# Altium schematic sheet Motor-X.SchDoc(Motor-X)
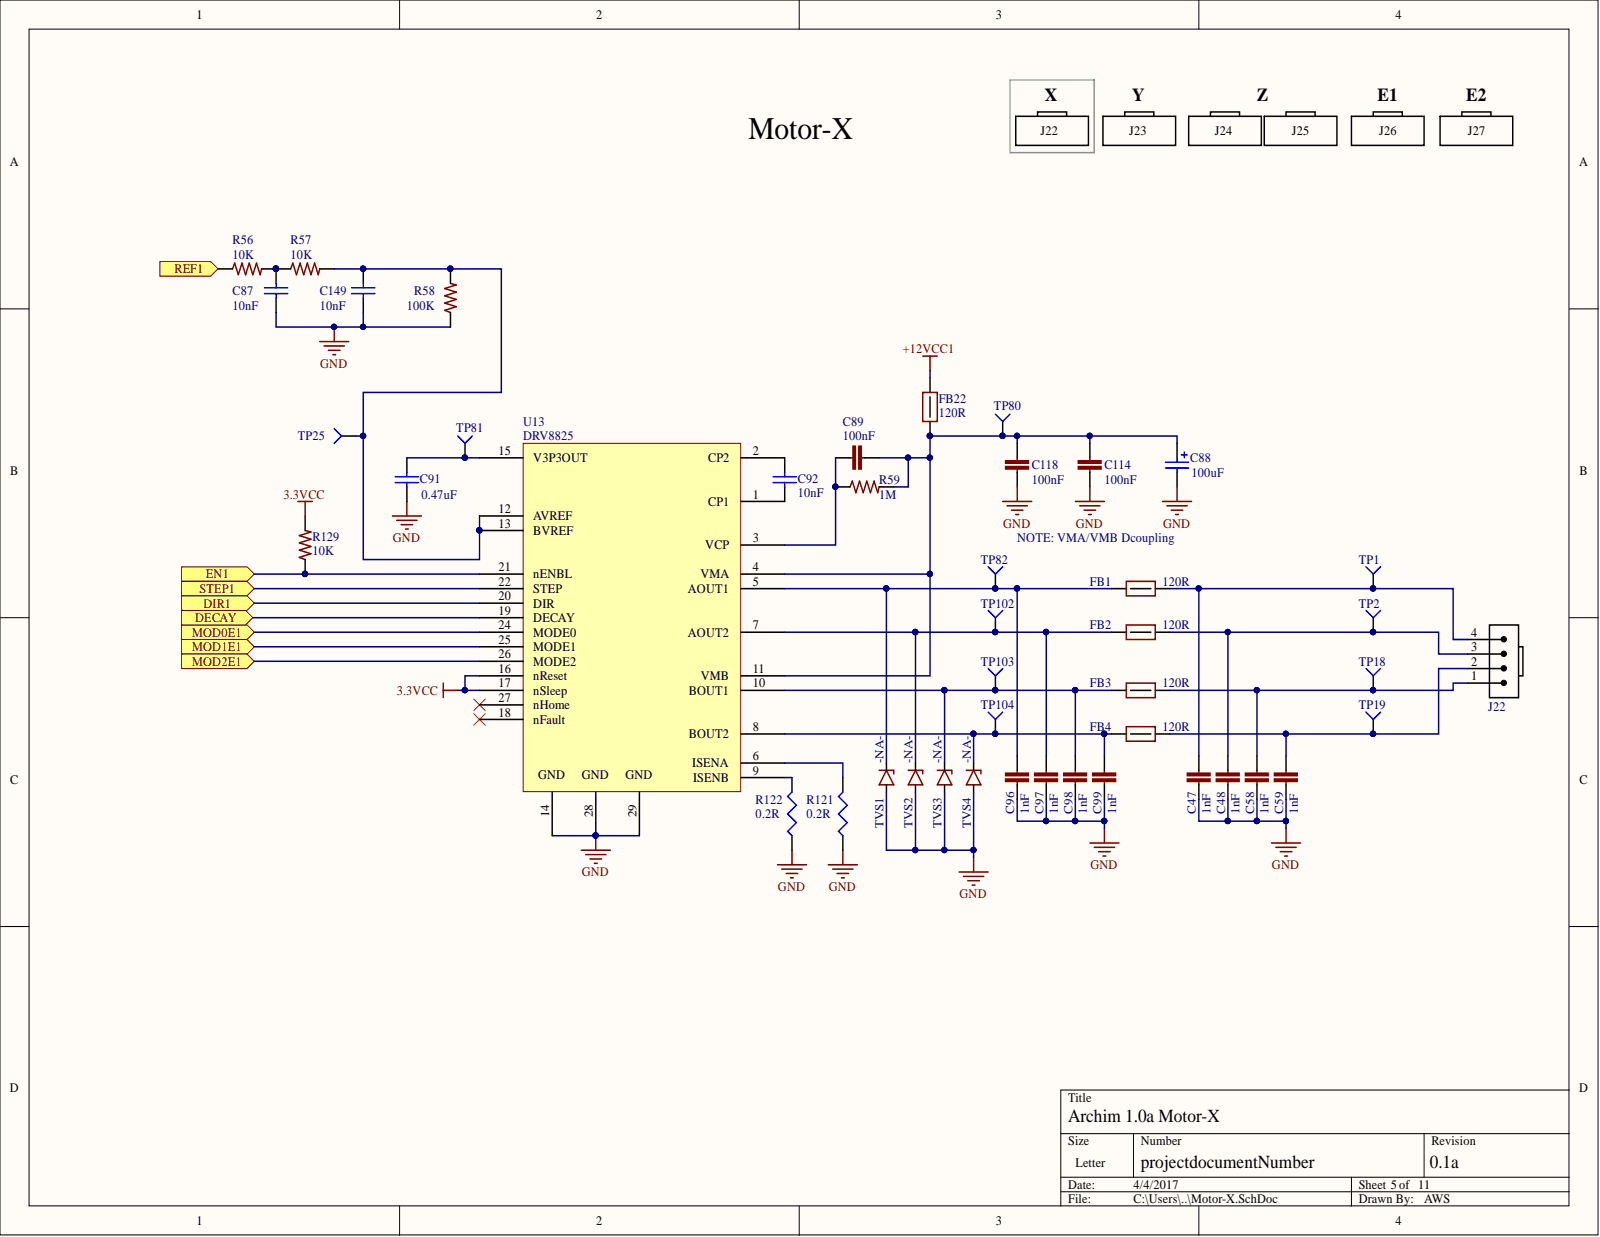
[diagram p0: Motor-X.SchDoc]

## Parts
C47  1nF  [C0402]
C48  1nF  [C0402]
C58  1nF  [C0402]
C59  1nF  [C0402]
C87  10nF  [C0402]
C88  value 100uF  [CAP-ELEC-063063077]  (hidden comment: UWT1V101MCL1GS)
C89  100nF  [C0402]
C91  value 0.47uF  [C0402]  (hidden comment: 0402)
C92  10nF  [C0402]
C96  1nF  [C0402]
C97  1nF  [C0402]
C98  1nF  [C0402]
C99  1nF  [C0402]
C114  100nF  [C0603]
C118  100nF  [C0603]
C149  10nF  [C0402]
FB1  120R  [FB0603]
FB2  120R  [FB0603]
FB3  120R  [FB0603]
FB4  120R  [FB0603]
FB22  120R  [FB0603]
J22  Molex 0705430003  [4POL254]  (value not drawn)
R56  10K  [R0402]
R57  10K  [R0402]
R58  100K  [R0402]
R59  1M  [R0402]
R121  value 0.2R  [2512R-0.55]  (hidden comment: 2512)
R122  value 0.2R  [2512R-0.55]  (hidden comment: 2512)
R129  10K  [R0402]
TP1  TP4  [TP-2]  (value not drawn)  (no BOM)
TP2  TP4  [TP-2]  (value not drawn)  (no BOM)
TP18  TP4  [TP-2]  (value not drawn)  (no BOM)
TP19  TP4  [TP-2]  (value not drawn)  (no BOM)
TP25  TP4  [TP-2]  (value not drawn)  (no BOM)
TP80  TP4  [TP-2]  (value not drawn)  (no BOM)
TP81  TP4  [TP-2]  (value not drawn)  (no BOM)
TP82  TP4  [TP-2]  (value not drawn)  (no BOM)
TP102  TP4  [TP-2]  (value not drawn)  (no BOM)
TP103  TP4  [TP-2]  (value not drawn)  (no BOM)
TP104  TP4  [TP-2]  (value not drawn)  (no BOM)
TVS1  value -NA-  [SMF]  (hidden comment: TVS 30V SMF)
TVS2  value -NA-  [SMF]  (hidden comment: TVS 30V SMF)
TVS3  value -NA-  [SMF]  (hidden comment: TVS 30V SMF)
TVS4  value -NA-  [SMF]  (hidden comment: TVS 30V SMF)
U13  DRV8825  [DRV8825PWPR]

## Ports
DECAY, DIR1, EN1, MOD0E1, MOD1E1, MOD2E1, REF1, STEP1

## Nets
3.3VCC: R129.2 U13.16(nReset) U13.17(nSleep)
+12VCC1: FB22.2
GND: C47.2 C48.2 C58.2 C59.2 C87.1 C88.2 C91.1 C96.2 C97.2 C98.2 C99.2 C114.2 C118.2 C149.1 R58.1 R121.1 R122.1 TVS1.A TVS2.A TVS3.A TVS4.A U13.14 U13.28 U13.29
DECAY: U13.19(DECAY) ; port DECAY
DIR1: U13.20(DIR) ; port DIR1
EN1: R129.1 U13.21(nENBL) ; port EN1
MOD0E1: U13.24(MODE0) ; port MOD0E1
MOD1E1: U13.25(MODE1) ; port MOD1E1
MOD2E1: U13.26(MODE2) ; port MOD2E1
REF1: R56.1 ; port REF1
STEP1: U13.22(STEP) ; port STEP1
NetC47_1: C47.1 FB1.2 J22.4 TP1.1
NetC48_1: C48.1 FB2.2 J22.3 TP2.1
NetC58_1: C58.1 FB3.2 J22.1 TP18.1
NetC59_1: C59.1 FB4.2 J22.2 TP19.1
NetC87_2: C87.2 R56.2 R57.1
NetC88_1: C88.1 C89.2 C114.1 C118.1 FB22.1 R59.2 TP80.1 U13.4(VMA) U13.11(VMB)
NetC89_1: C89.1 R59.1 U13.3(VCP)
NetC91_2: C91.2 TP81.1 U13.15(V3P3OUT)
NetC92_1: C92.1 U13.1(CP1)
NetC92_2: C92.2 U13.2(CP2)
NetC96_1: C96.1 FB1.1 TP82.1 TVS1.K U13.5(AOUT1)
NetC97_1: C97.1 FB2.1 TP102.1 TVS2.K U13.7(AOUT2)
NetC98_1: C98.1 FB3.1 TP103.1 TVS3.K U13.10(BOUT1)
NetC99_1: C99.1 FB4.1 TP104.1 TVS4.K U13.8(BOUT2)
NetC149_2: C149.2 R57.2 R58.2 TP25.1 U13.12(AVREF) U13.13(BVREF)
NetR121_2: R121.2 U13.6(ISENA)
NetR122_2: R122.2 U13.9(ISENB)

Not connected: U13 18(nFault) 27(nHome)

## Library data of the parts
C47 C48 C58 C59 C96-C99 = CAP 1nF 50V 0402 X7R: 1000pF 50V Ceramic Capacitor X7R 0402 (1005 Metric) 0.039" L x 0.020" W (1.00mm x 0.50mm); PART DESCRIPTION: 1000pF ±10% 50V Ceramic Capacitor X7R 0402 (1005 Metric); PART: 1nF 50V X7R 0402; MANUFACTURER #: GRM155R71H102KA01D; MANUFACTURER: Murata; TOLERANCE: 10%; VALUE: 1nF; Library Name: 3Delight.SCHLIB; EE_SPEC: 50V; DISTRIBUTOR #: 490-1303-2-ND; DISTRIBUTOR: Digikey; Component Kind: Standard
C87 C92 C149 = GRM155R71H103JA88D: CAP CER 10nF 50V X7R 0402; PART DESCRIPTION: 10000pF ±5% 50V Ceramic Capacitor X7R 0402 (1005 Metric); MANUFACTURER: Murata; TOLERANCE: 5%; VALUE: 10nF; Simulation: CAP; Signal Integrity: Cap Semi; PART: 10nF 50V X7R 0402; MANUFACTURER #: GRM155R71H103JA88D; Library Name: Tigershark3D.SchLib; EE_SPEC: 50V; DISTRIBUTOR #: 490-7763-1-ND; DISTRIBUTOR: Digikey; Component Kind: Standard
C88 = UWT1V101MCL1GS: CAP ALUM 100UF 35V 20% SMD; PART DESCRIPTION: 100µF 35V Aluminum Capacitors Radial, Can - SMD 1000 Hrs @ 105°C; PART: 100uF 35V SMD Al-El; MANUFACTURER: Nichicon; TOLERANCE: 20%; Simulation: CAP; Signal Integrity: Cap Pol; MANUFACTURER #: UWT1V101MCL1GS; Library Name: 3Delight.SCHLIB; EE_SPEC: 35V; DISTRIBUTOR #: 493-2203-2-ND; DISTRIBUTOR: Digikey; Component Kind: Standard
C89 = GRM155R71C104KA88D: CAP CER 0402 100nF 16V 10% X7R; PART DESCRIPTION: 0.10µF ±10% 16V Ceramic Capacitor X7R 0402 (1005 Metric); PART: 0.1uF 16V X7R 0402; MANUFACTURER: Murata; VALUE: 100nF; TOLERANCE: 10%; Simulation: Model Name; Signal Integrity: Model Name; MANUFACTURER #: GRM155R71C104KA88D; Library Name: 3Delight.SCHLIB; EE_SPEC: 16V; DISTRIBUTOR #: 490-3261-2-ND; DISTRIBUTOR: Digikey; Component Kind: Standard
C91 = GRM155R60J474KE19D: 0.47uF 6.3v C0402 - GRM155R60J474KE19D; PART DESCRIPTION: 0.47µF ±10% 6.3V Ceramic Capacitor X5R 0402 (1005 Metric); PART: 0.47uF 6.3V X5R 0402; MANUFACTURER: Murata; TOLERANCE: 10%; Simulation: CAP; Signal Integrity: Cap Semi; MANUFACTURER #: GRM155R60J474KE19D; Library Name: Tigershark3D.SchLib; EE_SPEC: 6.3V; DISTRIBUTOR #: 490-3266-2-ND; DISTRIBUTOR: Digikey; Component Kind: Standard
C114 C118 = C0603C104K5RACTU: CAP CER 0603 100nF 50V 10% X7R; PART DESCRIPTION: 0.10µF ±10% 50V Ceramic Capacitor X7R 0603 (1608 Metric); PART: 100nF 50V X7R 0603; MANUFACTURER: Kemet; VALUE: 100nF; TOLERANCE: 10%; Simulation: Model Name; Signal Integrity: Model Name; MANUFACTURER #: C0603C104K5RACTU; Library Name: 3Delight.SCHLIB; EE_SPEC: 50V; DISTRIBUTOR #: 399-5089-2-ND; DISTRIBUTOR: Digikey; Component Kind: Standard
FB1-FB4 FB22 = FERRITE 120R 3A 0603: FERRITE BEAD 120 OHM 0603 1LN; MANUFACTURER #: CIS10P121AC; MANUFACTURER2#: 490-5254-2-ND; MANUFACTURER: Samsung; VALUE: 120Rfb; TOLERANCE: -NA-; EE_SPEC: 3A; PART DESCRIPTION: FERRITE BEAD 120 OHM 0603 1LN; PART: FERRITE 120R 3A 0603; Library Name: 3Delight.SCHLIB; DISTRIBUTOR #: 1276-6351-2-ND; DISTRIBUTOR: Digikey; Component Kind: Standard
J22 = Molex 0705430003 Alt_1: Molex 4 Pin Clip Connector; PART DESCRIPTION: 4 Positions Header, Shrouded Connector 0.100" (2.54mm) Through Hole Tin; PART: 4 Pin Conn; MANUFACTURER #: 0705430038; VALUE: HDR4; TOLERANCE: -NA-; MANUFACTURER: Molex; EE_SPEC: -NA-; DISTRIBUTOR #: WM4826-ND; DISTRIBUTOR: Digikey; Component Kind: Standard; Library Name: Archim.SCHLIB
R56 R57 R129 = RC0402FR-0710KP: RES SMD 10K OHM 1% 1/16W 0402; PART DESCRIPTION: 10k Ohm ±1% 0.063W, 1/16W Chip Resistor 0402 (1005 Metric) Moisture Resistant Thick Film; PART: 10k 1% 0402; MANUFACTURER: Yageo; VALUE: 10K; TOLERANCE: 1%; Simulation: Model Name; Signal Integrity: Model Name; MANUFACTURER #: RC0402FR-0710KP; Library Name: 3Delight.SCHLIB; EE_SPEC: 1/16W; DISTRIBUTOR #: YAG1249TR-ND; DISTRIBUTOR: Digikey; Component Kind: Standard
R58 = RC1005F104CS: RES SMD 100K OHM 1% 1/16W 0402; PART DESCRIPTION: 100k Ohm ±1% 0.063W, 1/16W Chip Resistor 0402 (1005 Metric) Moisture Resistant Thick Film; PART: 100k 1% 0402; MANUFACTURER: Samsung; VALUE: 100K; TOLERANCE: 1%; Simulation: Model Name; Signal Integrity: Model Name; MANUFACTURER #: RC1005F104CS; Library Name: Tigershark3D.SchLib; EE_SPEC: 1/16W; DISTRIBUTOR #: 1276-3432-1-ND; DISTRIBUTOR: Digikey; Component Kind: Standard
R59 = ERJ2GEJ105X: RES 0402 1M 100mW 5%; PART DESCRIPTION: 1M Ohm ±5% 0.1W, 1/10W Chip Resistor 0402 (1005 Metric) Automotive AEC-Q200 Thick Film; PART: 1M 5% 0402; MANUFACTURER: Panasonic; EE_SPEC: 1/10W; VALUE: 1M; TOLERANCE: 5%; Simulation: Model Name; Signal Integrity: Model Name; MANUFACTURER #: ERJ2GEJ105X; Library Name: Tigershark3D.SchLib; DISTRIBUTOR #: P1.0MJTR-ND; DISTRIBUTOR: Digikey; Component Kind: Standard
R121 R122 = CSRN2512FTR200: RES SMD 0.2 OHM 1% 2W 2512; PART DESCRIPTION: 0.2 Ohm ±1% 2W Chip Resistor 2512 (6432 Metric) Current Sense Thick Film; PART: 0.2R 1% 2512; MANUFACTURER #: CSRN2512FKR200; MANUFACTURER: Stackpole ELectronics Inc; TOLERANCE: 1%; Simulation: RESISTOR; Signal Integrity: Res; EE_SPEC: 2W; DISTRIBUTOR #: CSRN2512FKR200TR-ND; DISTRIBUTOR: Digikey; Component Kind: Standard; Library Name: Archim.SCHLIB
TP1 TP2 TP18 TP19 TP25 TP80-TP82 TP102-TP104 = Socket: MANUFACTURER #: NOTAPART-Testpoint; Signal Integrity: Connector; Description: Socket; Library Name: Archim.SCHLIB
TVS1-TVS4 = TVS 30V SMF: TVS DIODE 30VWM 48.4VC SMF; PART: TVS Diode 48.4VC; MANUFACTURER #: SMF30A-E3-08; MANUFACTURER: Vishay; EE_SPEC: 30Vrs 48.4Vc; TOLERANCE: -NA-; PART DESCRIPTION: TVS DIODE 30VWM 48.4VC SMF; Library Name: 3Delight.SCHLIB; DISTRIBUTOR #: SMF30A-E3-08GITR-ND; DISTRIBUTOR: Digikey; Component Kind: Standard
U13 = DRV8825: DRV8825 Ti Stepper Driver; PART DESCRIPTION: Bipolar Motor Driver Power MOSFET Step/Direction 28-HTSSOP; MANUFACTURER: Texas Instruments; VALUE: -NA-; EE_SPEC: 8.2-45VDC; TOLERANCE: -NA-; PART: DRV8825; MANUFACTURER #: DRV8825PWPR; Library Name: Tigershark3D.SchLib; DISTRIBUTOR #: 296-29503-2-ND; DISTRIBUTOR: Digikey; Component Kind: Standard
